# Altium schematic sheet RoBeast_Current_Sense.SchDoc(RoBeast_Current_Sense)
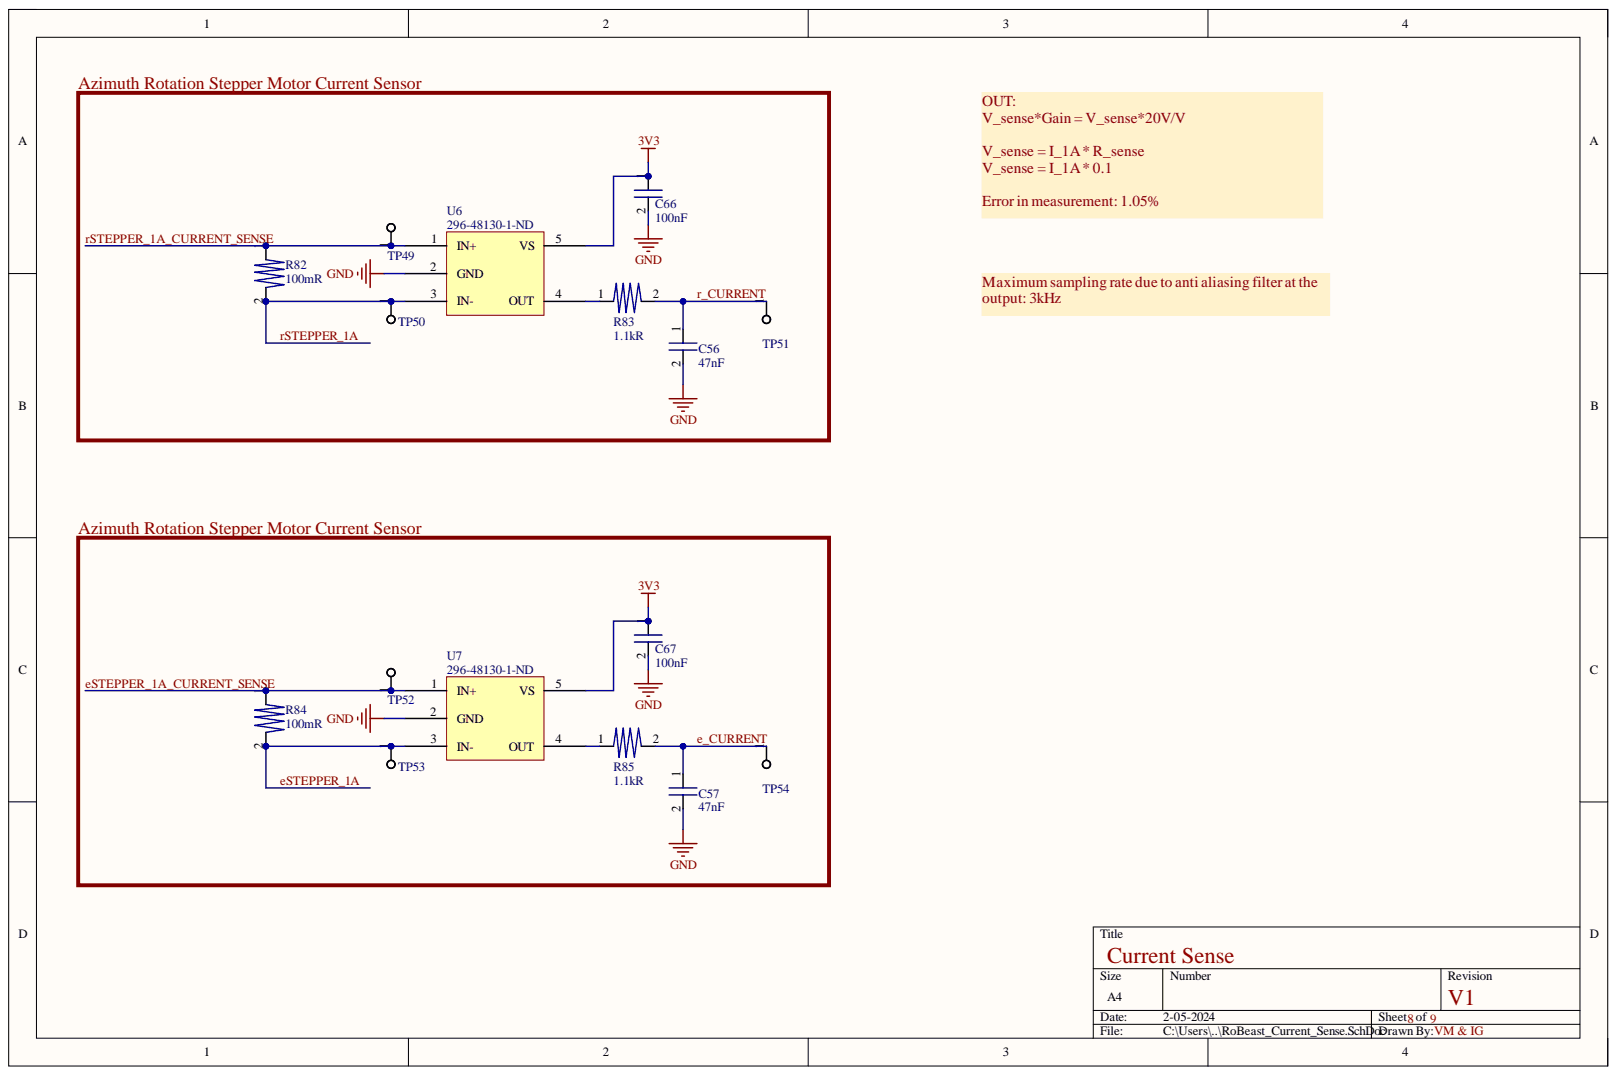
[diagram p0: RoBeast_Current_Sense.SchDoc]

## Parts
C56  47nF  [1206]
C57  47nF  [1206]
C66  100nF  [0805]
C67  100nF  [0805]
R82  100mR  [1206]
R83  1.1kR  [0805]
R84  100mR  [1206]
R85  1.1kR  [0805]
TP49  Test Point  [RCWCTE]  (value not drawn)
TP50  Test Point  [RCWCTE]  (value not drawn)
TP51  Test Point  [RCWCTE]  (value not drawn)
TP52  Test Point  [RCWCTE]  (value not drawn)
TP53  Test Point  [RCWCTE]  (value not drawn)
TP54  Test Point  [RCWCTE]  (value not drawn)
U6  Supplier Part Number 1: 296-48130-1-ND  [INA180B1IDBVR]  (hidden comment: Analog Current Sensor)
U7  Supplier Part Number 1: 296-48130-1-ND  [INA180B1IDBVR]  (hidden comment: Analog Current Sensor)

## Nets
3V3: C66.1 C67.1 U6.5(VS) U7.5(VS)
GND: C56.2 C57.2 C66.2 C67.2 U6.2(GND) U7.2(GND)
e_CURRENT: C57.1 R85.2 TP54.1
eSTEPPER_1A: R84.2 TP53.1 U7.3(IN-)
eSTEPPER_1A_CURRENT_SENSE: R84.1 TP52.1 U7.1(IN+)
r_CURRENT: C56.1 R83.2 TP51.1
rSTEPPER_1A: R82.2 TP50.1 U6.3(IN-)
rSTEPPER_1A_CURRENT_SENSE: R82.1 TP49.1 U6.1(IN+)
NetR83_1: R83.1 U6.4(OUT)
NetR85_1: R85.1 U7.4(OUT)

## Library data of the parts
C56 C57 = GCM31M5C1H473FA16L: Ceramic Capacitor, Multilayer, Ceramic, 50V, 1% +Tol, 1% -Tol, C0G, 30ppm/Cel TC, 0.047uF, Surface Mount, 1206; Manufacturer: Murata; Multilayer: Yes; Reference Standard: AEC-Q200; Capacitance: 47nF; Capacitor Type: Ceramic; Category: Ceramic Capacitors; Component Kind: Standard; ComponentLink1Description: https://datasheet.ciiva.com:443/pdfs/VipMasterIC/IC/MURE/MURE-S-A0004442191/MURE-S-A0004444721-1.pdf?src-supplier=IHS+Markit; ComponentLink1URL: https://datasheet.ciiva.com:443/pdfs/VipMasterIC/IC/MURE/MURE-S-A0004442191/MURE-S-A0004444721-1.pdf?src-supplier=IHS+Markit; Dielectric Material: Ceramic; Manufacturer Part Number: GCM31M5C1H473FA16L; Max Operating Temperature: 125°C; Min Operating Temperature: -55°C; Mount: Surface Mount; Negative Tolerance: 1%; Number of Pins: 2; Package Shape: Rectangular; Packaging: Tape and Reel; Positive Tolerance: 1%; Pricing 1: 1=0.87, 10=0.611, 50=0.5148, 100=0.4192, 500=0.34932, 1000=0.29417 (USD); Rated DC Voltage (URdc): 50V; Reach SVHC Compliant: Yes; RoHS: Yes; RoHS Compliant: Yes; Size Code: 1206; Stock 1: 62477; Supplier 1: Digikey; Supplier Part Number 1: 490-GCM31M5C1H473FA16LCT-ND; Temperature Characteristics Code: C0G; Termination: Wraparound; Library Name: RoBeast_Schematic_Library.SchLib
C66 C67 = C0805C104J5RAC7800: Ceramic Capacitor, Multilayer, Ceramic, 50V, 5% +Tol, 5% -Tol, X7R, 15% TC, 0.1uF, Surface Mount, 0805; Manufacturer: KEMET; Manufacturer Part Number: C0805C104J5RACTU; Multilayer: Yes; Capacitance: 100nF; Capacitor Type: Ceramic; Category: Ceramic Capacitors; Component Kind: Standard; ComponentLink1Description: http://capacitoredge.kemet.com:80/capedge2/intellispec.jsp?pn=C0805C104J5RACTU&displayPN=C0805C104J5RACTU&src-supplier=IHS+Markit; ComponentLink1URL: http://capacitoredge.kemet.com:80/capedge2/intellispec.jsp?pn=C0805C104J5RACTU&displayPN=C0805C104J5RACTU&src-supplier=IHS+Markit; ComponentLink2Description: https://datasheet.ciiva.com/7842/1732721-7842179.pdf?src-supplier=Element14; ComponentLink2URL: https://datasheet.ciiva.com/7842/1732721-7842179.pdf?src-supplier=Element14; ComponentLink3Description: https://datasheet.ciiva.com/28682/1851736-28682223.pdf?src-supplier=Element14; ComponentLink3URL: https://datasheet.ciiva.com/28682/1851736-28682223.pdf?src-supplier=Element14; ComponentLink4Description: https://datasheet.ciiva.com/7258/1828800-7258413.pdf?src-supplier=Newark; ComponentLink4URL: https://datasheet.ciiva.com/7258/1828800-7258413.pdf?src-supplier=Newark; ComponentLink5Description: https://datasheet.ciiva.com/14589/70095383-14589015.pdf?src-supplier=Allied+Electronics; ComponentLink5URL: https://datasheet.ciiva.com/14589/70095383-14589015.pdf?src-supplier=Allied+Electronics; ComponentLink6Description: https://datasheet.ciiva.com/5417/kem-c1002-x7r-smd-5417352.pdf?src-supplier=Mouser; ComponentLink6URL: https://datasheet.ciiva.com/5417/kem-c1002-x7r-smd-5417352.pdf?src-supplier=Mouser; ComponentLink7Description: https://datasheet.ciiva.com/39122/docfinderpartnumberc0402c102k5ractu-39122924.pdf?src-supplier=Onlinecomponents.com; ComponentLink7URL: https://datasheet.ciiva.com/39122/docfinderpartnumberc0402c102k5ractu-39122924.pdf?src-supplier=Onlinecomponents.com; Dielectric Material: Ceramic; JESD-609 Code: e3; Max Operating Temperature: 125°C; Min Operating Temperature: -55°C; Mount: Surface Mount; Negative Tolerance: 5%; Number of Pins: 2; Package Shape: Rectangular; Packaging: Tape and Reel; Positive Tolerance: 5%; Pricing 1: 1=0.18, 10=0.122, 50=0.0732, 100=0.0576, 500=0.04252, 1000=0.03519 (USD); Rated DC Voltage (URdc): 50V; Reach SVHC Compliant: Yes; RoHS: Yes; RoHS Compliant: Yes; Size Code: 0805; Stock 1: 605061; Supplier 1: Digikey; Supplier Part Number 1: 399-C0805C104J5RAC7800CT-ND; Temperature Characteristics Code: X7R; Terminal Finish: Nickel; Termination: Wraparound; Library Name: RoBeast_Schematic_Library.SchLib
R82 R84 = RLC73PH2BR10FTD: Current Sensing Resistor, RLC73PH 2B R10, 1 percent, 5K RL | TE Connectivity RLC73PH2BR10FTD; Category: Chip SMD Resistors; Component Kind: Standard; Manufacturer: TE Connectivity; Manufacturer Part Number: RLC73PH2BR10FTD; Pricing 1: 1=0.45, 10=0.308, 25=0.24, 50=0.177, 100=0.1232, 250=0.10732, 500=0.0885, 1000=0.06403 (USD); RoHS: No; Stock 1: 3970; Supplier 1: Digikey; Supplier Part Number 1: 1712-RLC73PH2BR10FTDCT-ND; Library Name: RoBeast_Schematic_Library.SchLib
R83 R85 = RCS08051K10FKEA: Fixed Resistor, Metal Glaze/thick Film, 0.4W, 1100ohm, 150V, 1% +/-Tol, 100ppm/Cel, Surface Mount, 0805; Manufacturer: Vishay Dale; Category: Chip SMD Resistors; Component Kind: Standard; ComponentLink1Description: https://datasheet.ciiva.com:443/pdfs/VipMasterIC/IC/VISH/VISH-S-A0007978036/VISH-S-A0007978036-1.pdf?src-supplier=IHS+Markit; ComponentLink1URL: https://datasheet.ciiva.com:443/pdfs/VipMasterIC/IC/VISH/VISH-S-A0007978036/VISH-S-A0007978036-1.pdf?src-supplier=IHS+Markit; ComponentLink2Description: http://www.vishay.com/docs/20065/rcse3.pdf?src-supplier=Digikey; ComponentLink2URL: http://www.vishay.com/docs/20065/rcse3.pdf?src-supplier=Digikey; Manufacturer Part Number: RCS08051K10FKEA; Pricing 1: 1=0.2, 10=0.104, 50=0.0712, 100=0.0613, 500=0.045, 1000=0.03986 (USD); RoHS: Yes; Stock 1: 9985; Supplier 1: Digikey; Supplier Part Number 1: 541-RCS08051K10FKEACT-ND; Library Name: RoBeast_Schematic_Library.SchLib
TP49-TP54 = RCWCTE: Testing/Accessories; Manufacturer: KOA Speer; Package Height: 2mm; Package Length: 3.2mm; Package Width: 1.6mm; Rated Temperature: 70°C; Reference Standard: AEC-Q200; Resistance: 0R; Category: Chip SMD Resistors; Component Kind: Standard; ComponentLink1Description: https://datasheet.ciiva.com:443/pdfs/VipMasterIC/IC/KOAS/KOASS03065/KOASS03065-1.pdf?src-supplier=IHS+Markit; ComponentLink1URL: https://datasheet.ciiva.com:443/pdfs/VipMasterIC/IC/KOAS/KOASS03065/KOASS03065-1.pdf?src-supplier=IHS+Markit; ComponentLink2Description: https://datasheet.ciiva.com/34302/1876186-34302940.pdf?src-supplier=Jameco; ComponentLink2URL: https://datasheet.ciiva.com/34302/1876186-34302940.pdf?src-supplier=Jameco; ComponentLink3Description: https://datasheet.ciiva.com/5556/rc-5556236.pdf?src-supplier=Mouser; ComponentLink3URL: https://datasheet.ciiva.com/5556/rc-5556236.pdf?src-supplier=Mouser; ComponentLink4Description: https://datasheet.ciiva.com/26821/getdatasheetpartid-110197-26821157.pdf?src-supplier=Verical; ComponentLink4URL: https://datasheet.ciiva.com/26821/getdatasheetpartid-110197-26821157.pdf?src-supplier=Verical; Construction: Chip; JESD-609 Code: e2; Manufacturer Part Number: RCWCTE; Max Operating Temperature: 125°C; Min Operating Temperature: -55°C; Mount: Surface Mount; Number of Pins: 2; Package Shape: Rectangular; Package Style: SMTMeter; Packaging: Tape and Reel; Pricing 1: 1=0.16, 10=0.137, 25=0.1212, 50=0.1132, 100=0.105, 250=0.09292, 500=0.08888, 1000=0.07272 (USD); Reach SVHC Compliant: Yes; Resistor Type: FIXED RESISTOR; RoHS: Yes; RoHS Compliant: Yes; Size Code: 1206; Stock 1: 132357; Supplier 1: Digikey; Supplier Part Number 1: 2019-RCWCTECT-ND; Terminal Finish: Copper; Termination: Wraparound; Library Name: RoBeast_Schematic_Library.SchLib
U6 U7 = INA180B1IDBVR: Operational Amplifier, 1 Func, 500uV Offset-Max, PDSO5; Manufacturer: Texas Instruments; Common-mode Reject Ratio-Nom: 100dB; Number of Functions: 1; Package Body Material: Plastic; Slew Rate: 2V/µs; Supply Voltage Limit-Max: 6V; Supply Voltage-Nom (Vsup): 5V; Unity Gain BW-Nom: 350kHz; Amplifier Type: OPERATIONAL AMPLIFIER; Category: Amplifiers - Op Amps, Buffer, Instrumentation; Component Kind: Standard; ComponentLink1Description: https://www.ti.com:443/lit/ds/symlink/ina180.pdf?src-supplier=IHS+Markit; ComponentLink1URL: https://www.ti.com:443/lit/ds/symlink/ina180.pdf?src-supplier=IHS+Markit; ComponentLink2Description: http://www.ti.com/general/docs/suppproductinfo.tsp?distId=10&amp;gotoUrl=http%3A%2F%2Fwww.ti.com%2Flit%2Fgpn%2Fina180&src-supplier=Digikey; ComponentLink2URL: http://www.ti.com/general/docs/suppproductinfo.tsp?distId=10&amp;gotoUrl=http%3A%2F%2Fwww.ti.com%2Flit%2Fgpn%2Fina180&src-supplier=Digikey; ComponentLink3Description: http://www.ti.com/lit/ds/symlink/ina180.pdf?src-supplier=Digikey; ComponentLink3URL: http://www.ti.com/lit/ds/symlink/ina180.pdf?src-supplier=Digikey; Height - Seated (Max): 1.45mm; Input Offset Voltage-Max: 500uV; JESD-30 Code: R-PDSO-G5; JESD-609 Code: e3; Length: 2.9mm; Manufacturer Part Number: INA180B1IDBVR; Max Operating Temperature: 125°C; Min Operating Temperature: -40°C; Moisture Sensitivity Level: 1; Number of Pins: 5; Package Code: LSSOP; Package Shape: Rectangular; Package Style: SMALL OUTLINE, LOW PROFILE, SHRINK PITCHMeter; Peak Reflow Temperature (Cel): 260°C; Pricing 1: 1=0.4, 10=0.346, 25=0.3232, 100=0.2587, 250=0.2402, 500=0.20324, 1000=0.15705 (USD); Reach SVHC Compliant: Yes; RoHS: Yes; RoHS Compliant: Yes; Stock 1: 546; Supplier 1: Digikey; Surface Mount: Yes; Temperature Grade: AUTOMOTIVE; Terminal Finish: Tin; Terminal Form: Gull Wing; Terminal Pitch: 0.95mm; Terminal Position: DUAL; Width: 1.6mm; Library Name: RoBeast_Schematic_Library.SchLib
